# Altium schematic sheet esp32.SchDoc
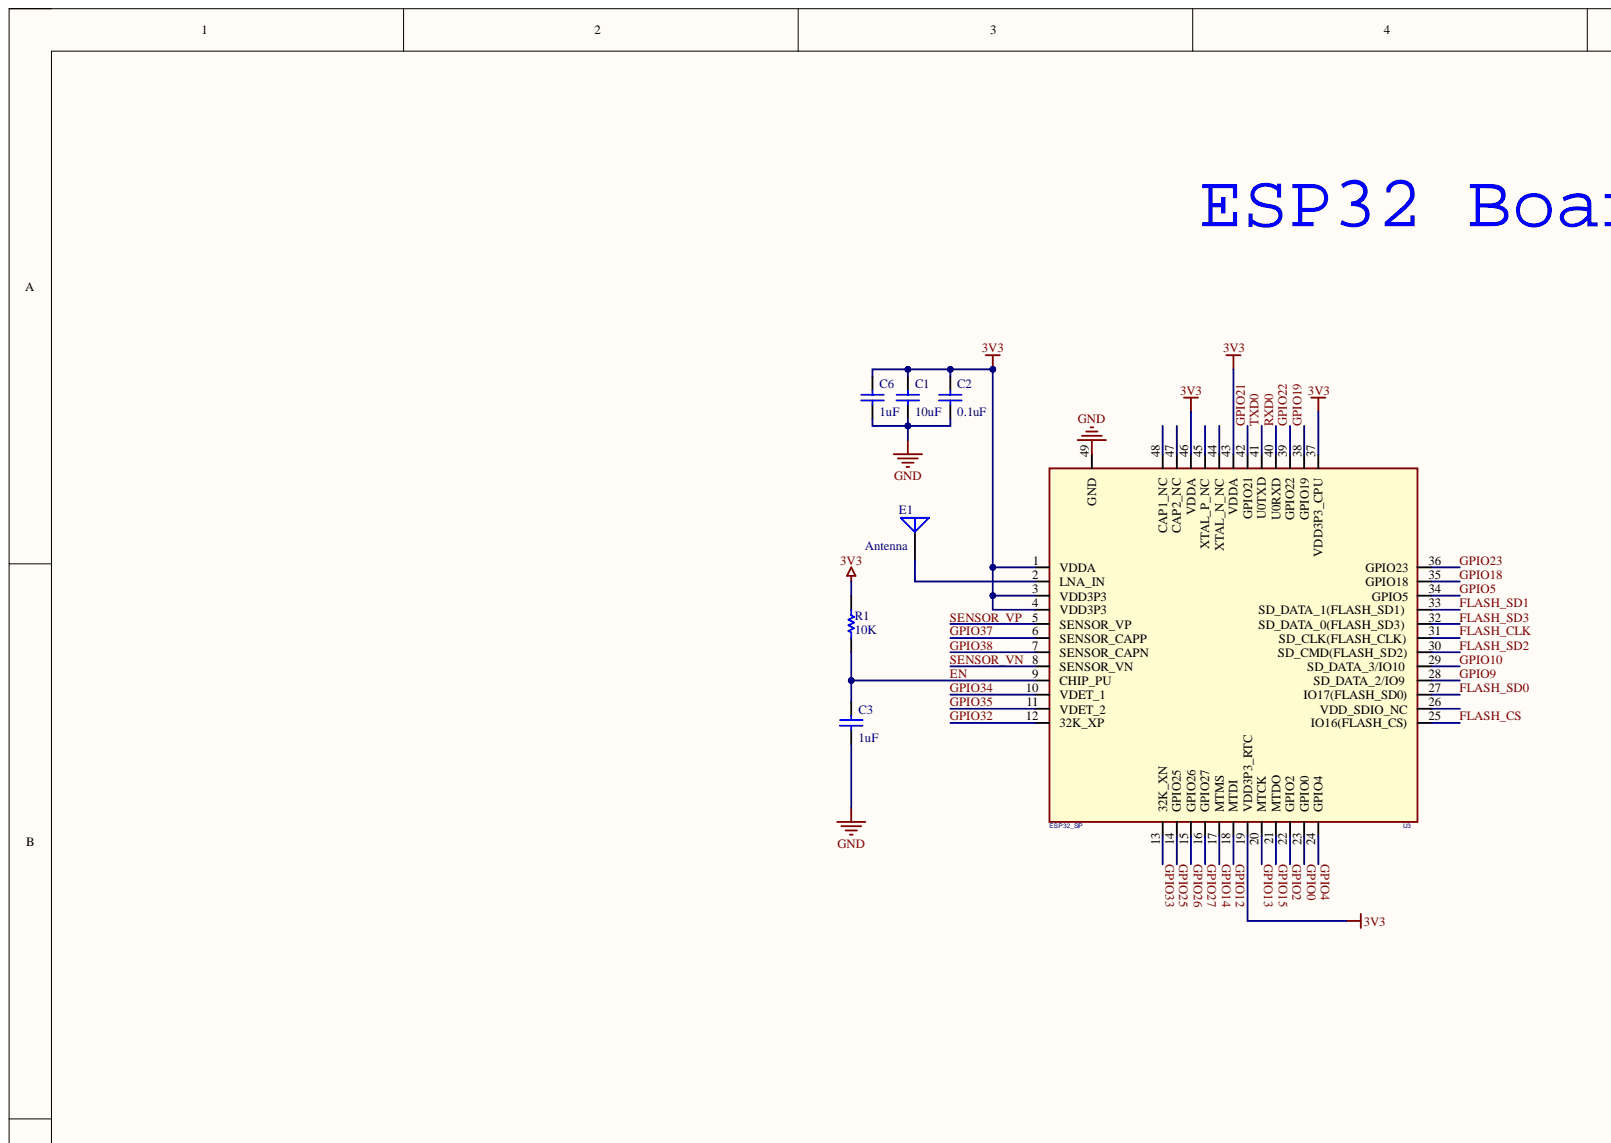
[diagram p0: esp32.SchDoc, top left]
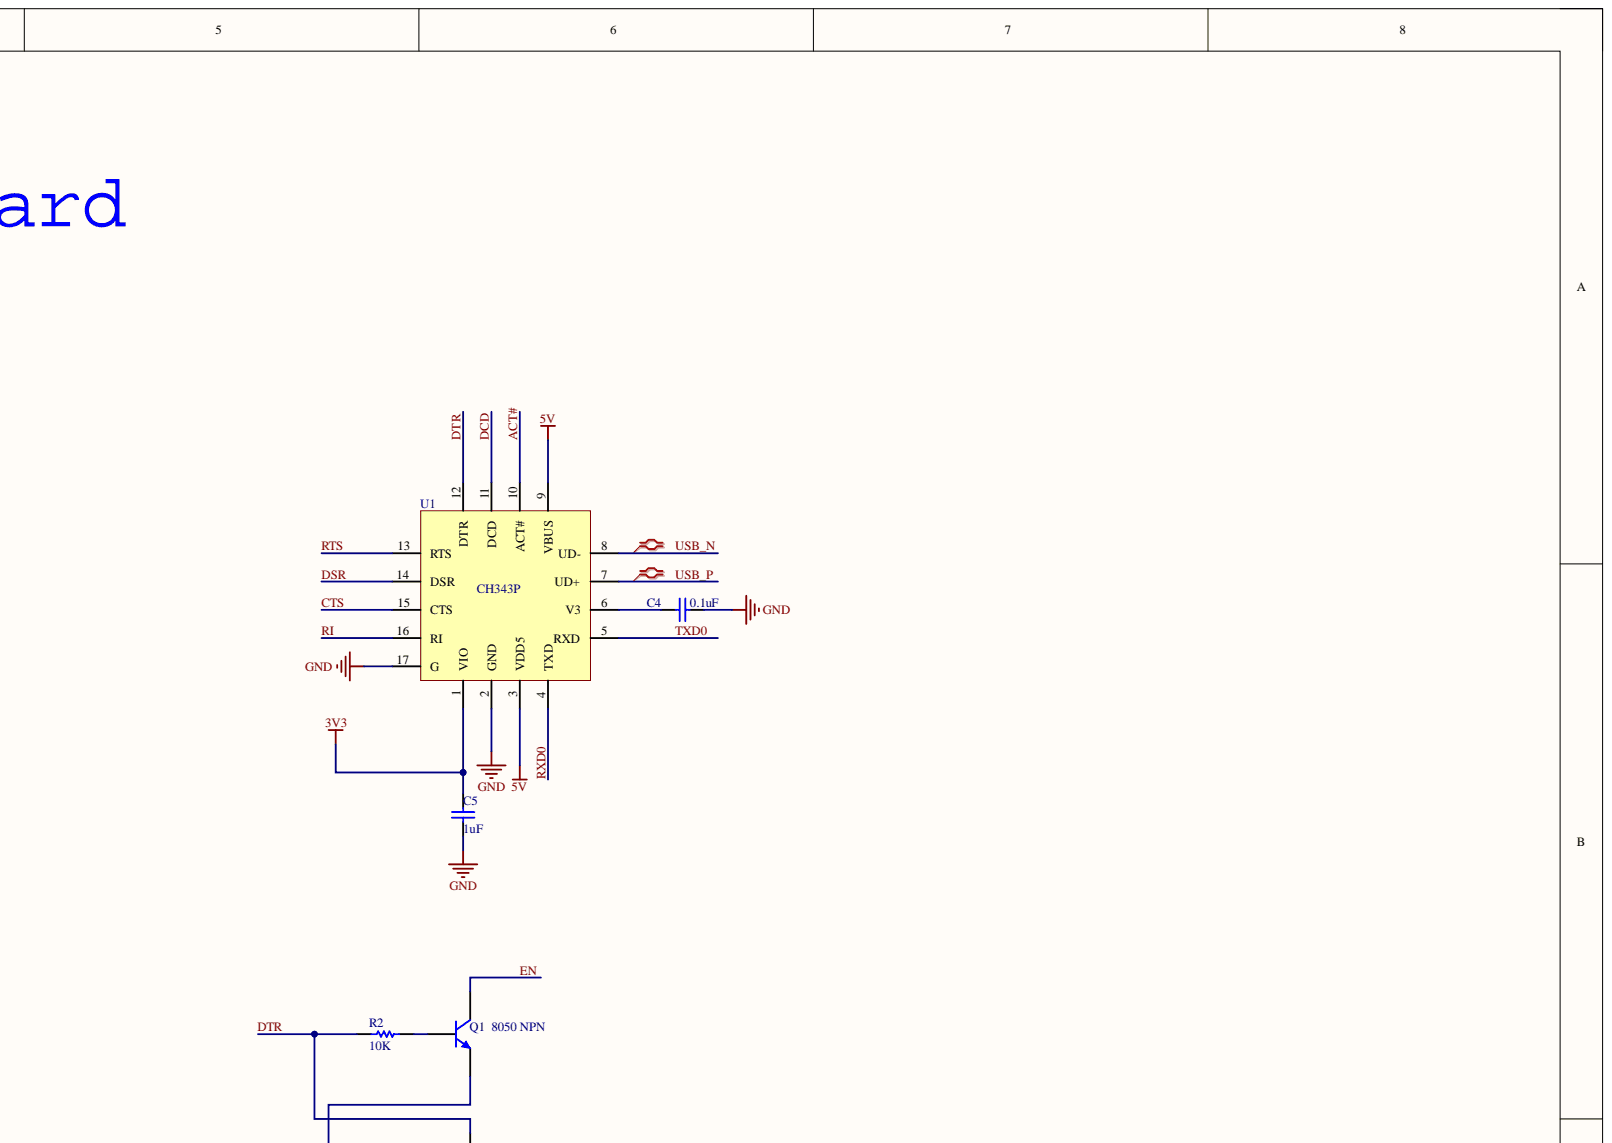
[diagram p1: esp32.SchDoc, top right]
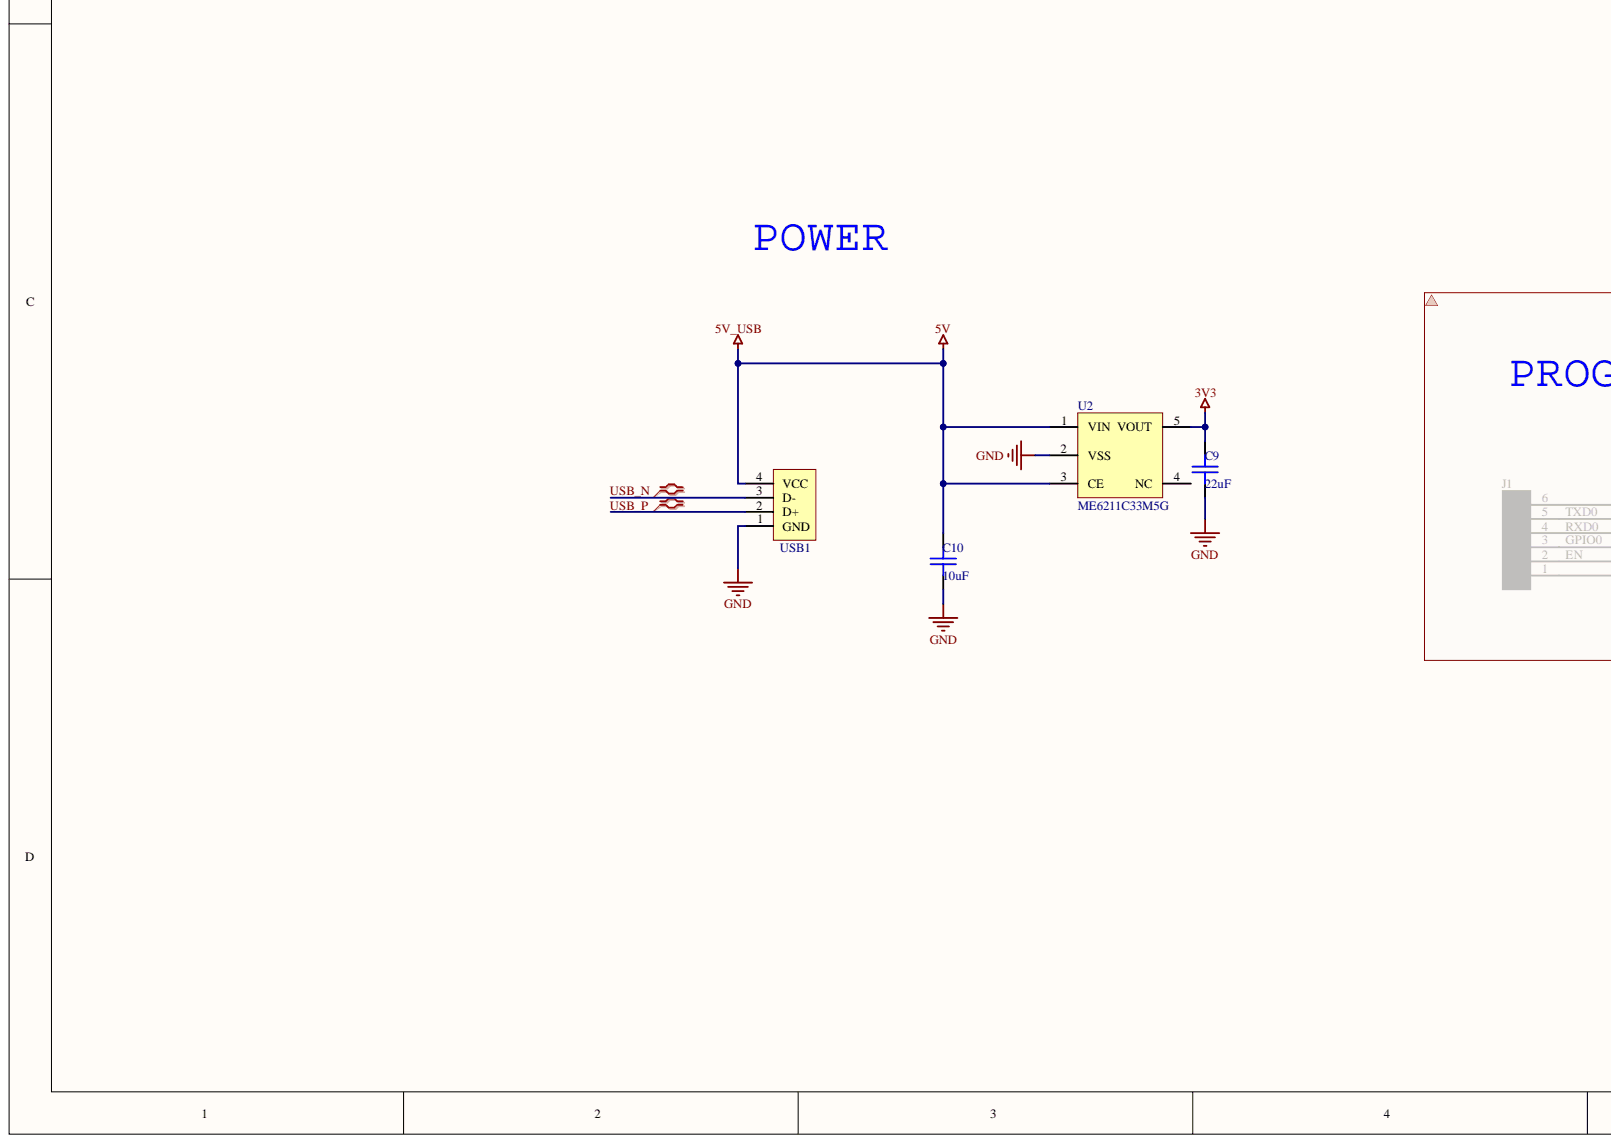
[diagram p2: esp32.SchDoc, bottom left]
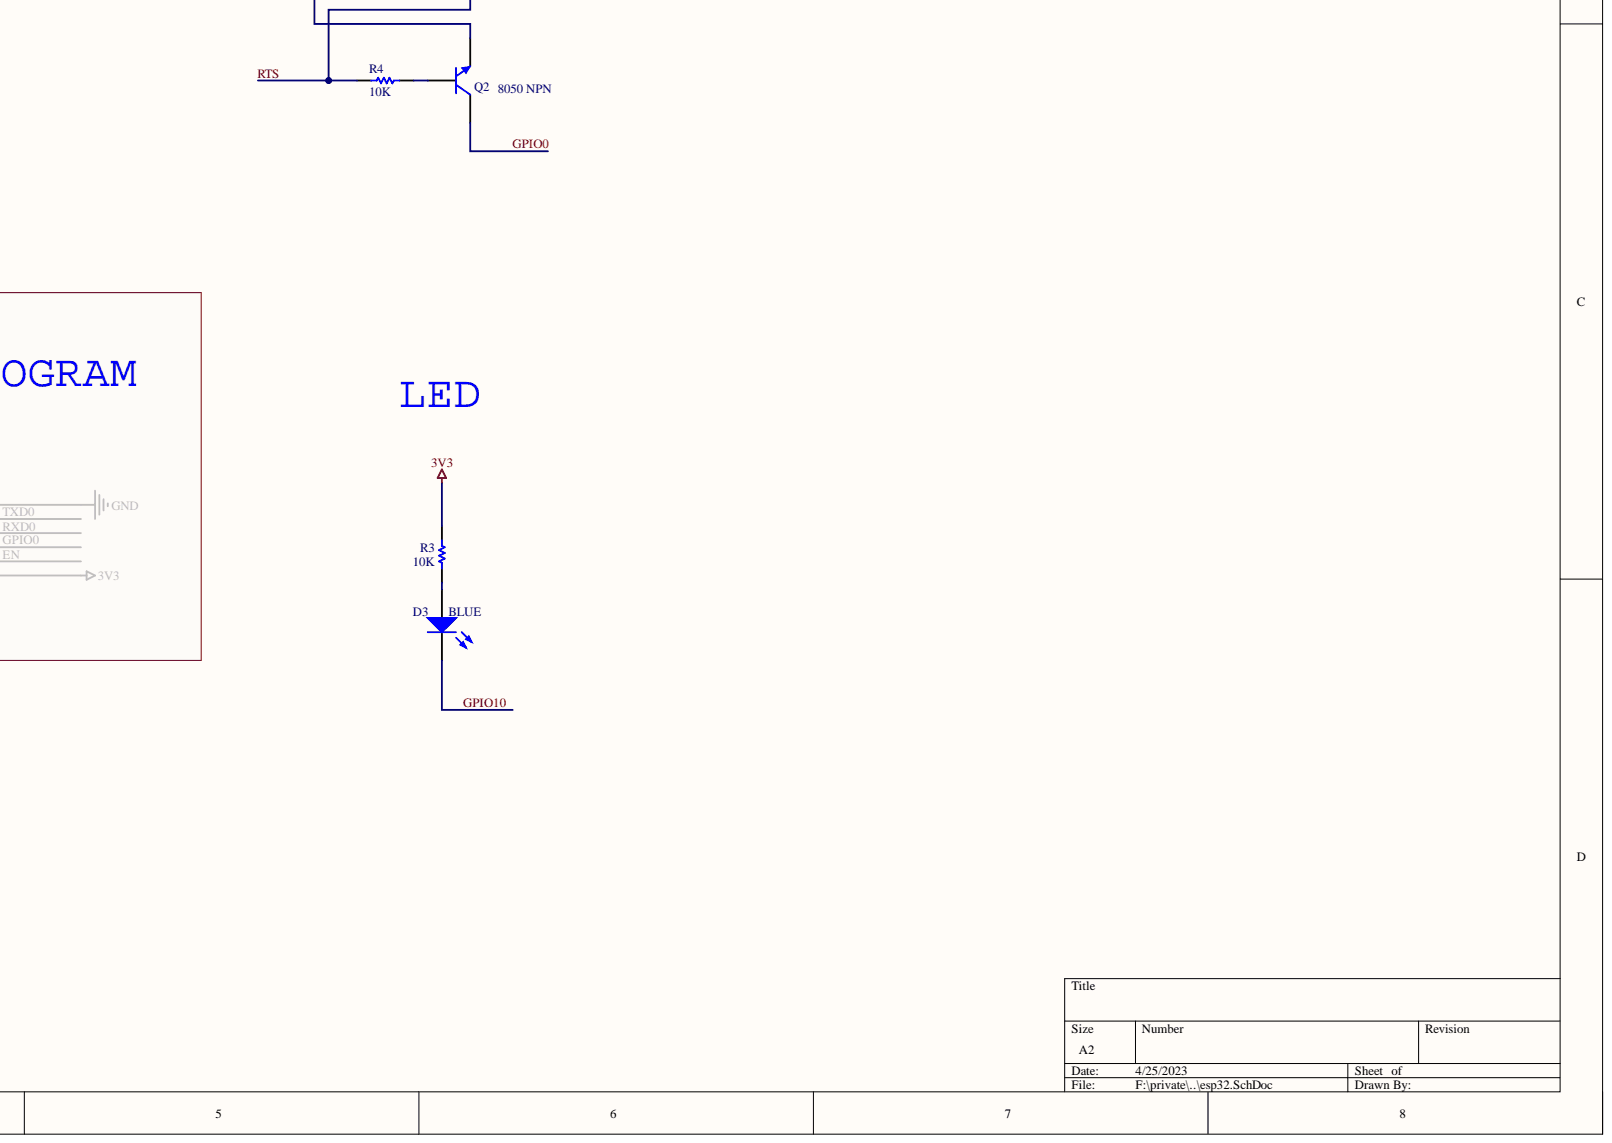
[diagram p3: esp32.SchDoc, bottom right]

## Parts
C1  10uF  [C 0402_M_new]
C2  0.1uF  [C 0402_M_new]
C3  1uF  [C 0402_M_new]
C4  0.1uF  [C 0402_M_new]
C5  1uF  [C 0402_M_new]
C6  1uF  [C 0402_M_new]
C9  22uF  [0603_C]
C10  10uF  [0603_C]
D3  BLUE  [0603_LED_B]
E1  Antenna  [CA-C03]
Q1  value 8050 NPN  [SOT23]  (hidden comment: MMBT8050 NPN)
Q2  value 8050 NPN  [SOT23]  (hidden comment: MMBT8050 NPN)
R1  10K  [R 0402_M_new]
R2  10K  [R 0402_M_new]
R3  10K  [R 0402_M_new]
R4  10K  [R 0402_M_new]
U1  CH343P  [TQFN-16_L3.0-W3.0-P0.50-BL-EP1.7]
U2  ME6211C33M5G  [SOT23-5]
U3  ESP32_SIP  [QFN-48_7X7]
USB1  USB  [USB_A/P_B]

## Nets
3V3: C1.2 C2.2 C5.1 C6.2 C9.1 R1.1 R3.1 U1.1(VIO) U2.5(VOUT) U3.1(VDDA) U3.3(VDD3P3) U3.4(VDD3P3) U3.19(VDD3P3_RTC) U3.37(VDD3P3_CPU) U3.43(VDDA) U3.46(VDDA)
5V: C10.1 U1.3(VDD5) U1.9(VBUS) U2.1(VIN) U2.3(CE) USB1.4(VCC)
GND: C1.1 C2.1 C3.1 C4.2 C5.2 C6.1 C9.2 C10.2 U1.2(GND) U1.17(G) U2.2(VSS) U3.49(GND) USB1.1(GND)
ACT#: U1.10(ACT#)
CTS: U1.15(CTS)
DCD: U1.11(DCD)
DSR: U1.14(DSR)
DTR: Q2.3 R2.2 U1.12(DTR)
EN: C3.2 Q1.1 R1.2 U3.9(CHIP_PU)
FLASH_CLK: U3.31(SD_CLK(FLASH_CLK))
FLASH_CS: U3.25(IO16(FLASH_CS))
FLASH_SD0: U3.27(IO17(FLASH_SD0))
FLASH_SD1: U3.33(SD_DATA_1(FLASH_SD1))
FLASH_SD2: U3.30(SD_CMD(FLASH_SD2))
FLASH_SD3: U3.32(SD_DATA_0(FLASH_SD3))
GPIO0: Q2.1 U3.23(GPIO0)
GPIO2: U3.22(GPIO2)
GPIO4: U3.24(GPIO4)
GPIO5: U3.34(GPIO5)
GPIO9: U3.28(SD_DATA_2/IO9)
GPIO10: D3.2 U3.29(SD_DATA_3/IO10)
GPIO12: U3.18(MTDI)
GPIO13: U3.20(MTCK)
GPIO14: U3.17(MTMS)
GPIO15: U3.21(MTDO)
GPIO18: U3.35(GPIO18)
GPIO19: U3.38(GPIO19)
GPIO21: U3.42(GPIO21)
GPIO22: U3.39(GPIO22)
GPIO23: U3.36(GPIO23)
GPIO25: U3.14(GPIO25)
GPIO26: U3.15(GPIO26)
GPIO27: U3.16(GPIO27)
GPIO32: U3.12(32K_XP)
GPIO33: U3.13(32K_XN)
GPIO34: U3.10(VDET_1)
GPIO35: U3.11(VDET_2)
GPIO37: U3.6(SENSOR_CAPP)
GPIO38: U3.7(SENSOR_CAPN)
RI: U1.16(RI)
RTS: Q1.3 R4.2 U1.13(RTS)
RXD0: U1.4(TXD) U3.40(U0RXD)
SENSOR_VN: U3.8(SENSOR_VN)
SENSOR_VP: U3.5(SENSOR_VP)
TXD0: U1.5(RXD) U3.41(U0TXD)
USB_N: U1.8(UD-) USB1.3(D-)
USB_P: U1.7(UD+) USB1.2(D+)
NetC4_1: C4.1 U1.6(V3)
NetD3_1: D3.1 R3.2
NetE1_1: E1.1 U3.2(LNA_IN)
NetQ1_2: Q1.2 R2.1
NetQ2_2: Q2.2 R4.1

Not connected: U2 4(NC); U3 26(VDD_SDIO_NC) 44(XTAL_N_NC) 45(XTAL_P_NC) 47(CAP2_NC) 48(CAP1_NC)

## Library data of the parts
C1-C3 C6 = C0603: Capacitor; Component Kind: Standard; LatestRevisionDate: 17-Jul-2002; LatestRevisionNote: Re-released for DXP Platform.; Library Name: MYLIB.IntLib; PackageDescription: Radial Cap, Thru-Hole; 2 Leads; 0.3 in Pin Spacing; PackageReference: RAD-0.3; Published: 8-Jun-2000; Signal Integrity: Cap; Simulation: CAP; Publisher: Altium Limited
  C1: Value: 10uF
  C2: Value: 0.1uF
  C3 C6: Value: 1uF
C4 C5 = C: Capacitor; Component Kind: Standard; Library Name: nanoUART.SCHLIB
C9 C10 = SMT_C_0805: Surface mount capacitor 0805; Component Kind: Standard; Library Name: Vowstar_Basic_Device.IntLib
D3 = D0603: Typical RED, GREEN, YELLOW, AMBER GaAs LED; Component Kind: Standard; LatestRevisionDate: 17-Jul-2002; LatestRevisionNote: Re-released for DXP Platform.; Library Name: MYLIB.IntLib; PackageDescription: SM LED; 2 Flat Leads; PackageReference: 3.2X1.6X1.1; PackageVersion: Feb-2002; Published: 8-Jun-2000; Simulation: LED2; Publisher: Altium Limited
E1 = Antenna: Generic Antenna; Component Kind: Standard; LatestRevisionDate: 17-Jul-2002; LatestRevisionNote: Re-released for DXP Platform.; Library Name: Miscellaneous Devices.IntLib; PackageReference: PIN1; Published: 24-Mar-1999; Publisher: Altium Limited
Q1 Q2 = NPN: NPN Bipolar Transistor; Code_JEDEC: TO-226-AA; Component Kind: Standard; LatestRevisionDate: 17-Jul-2002; LatestRevisionNote: Re-released for DXP Platform.; Library Name: Miscellaneous Devices.IntLib; PackageDescription: TO, Flat Index; 3 In-Line, Axial Leads; Body Dia. 4.8mm; Leads 0.55 x 0.51 mm (max); PackageReference: TO-226-AA; Published: 8-Jun-2000; Publisher: Altium Limited
R1-R4 = R0603: Resistor; Component Kind: Standard; LatestRevisionDate: 17-Jul-2002; LatestRevisionNote: Re-released for DXP Platform.; Library Name: MYLIB.IntLib; PackageDescription: Axial Device, Thru-Hole; 2 Leads; 0.3 in Pin Spacing; PackageReference: AXIAL-0.3; Published: 8-Jun-2000; Signal Integrity: Res; Simulation: RESISTOR; Value: 10K; Publisher: Altium Limited
U3 = ESP32-PICO: Component Kind: Standard; Library Name: MyPcbLib.IntLib
USB1 = USB_G: Component Kind: Standard; Library Name: nanoDAP.SCHLIB
U1 = CH343P: Component Kind: Standard; Library Name: nanoUART.SCHLIB
U2 = ME6211C33M5G: Component Kind: Standard
